# Altium schematic sheet Power.SchDoc
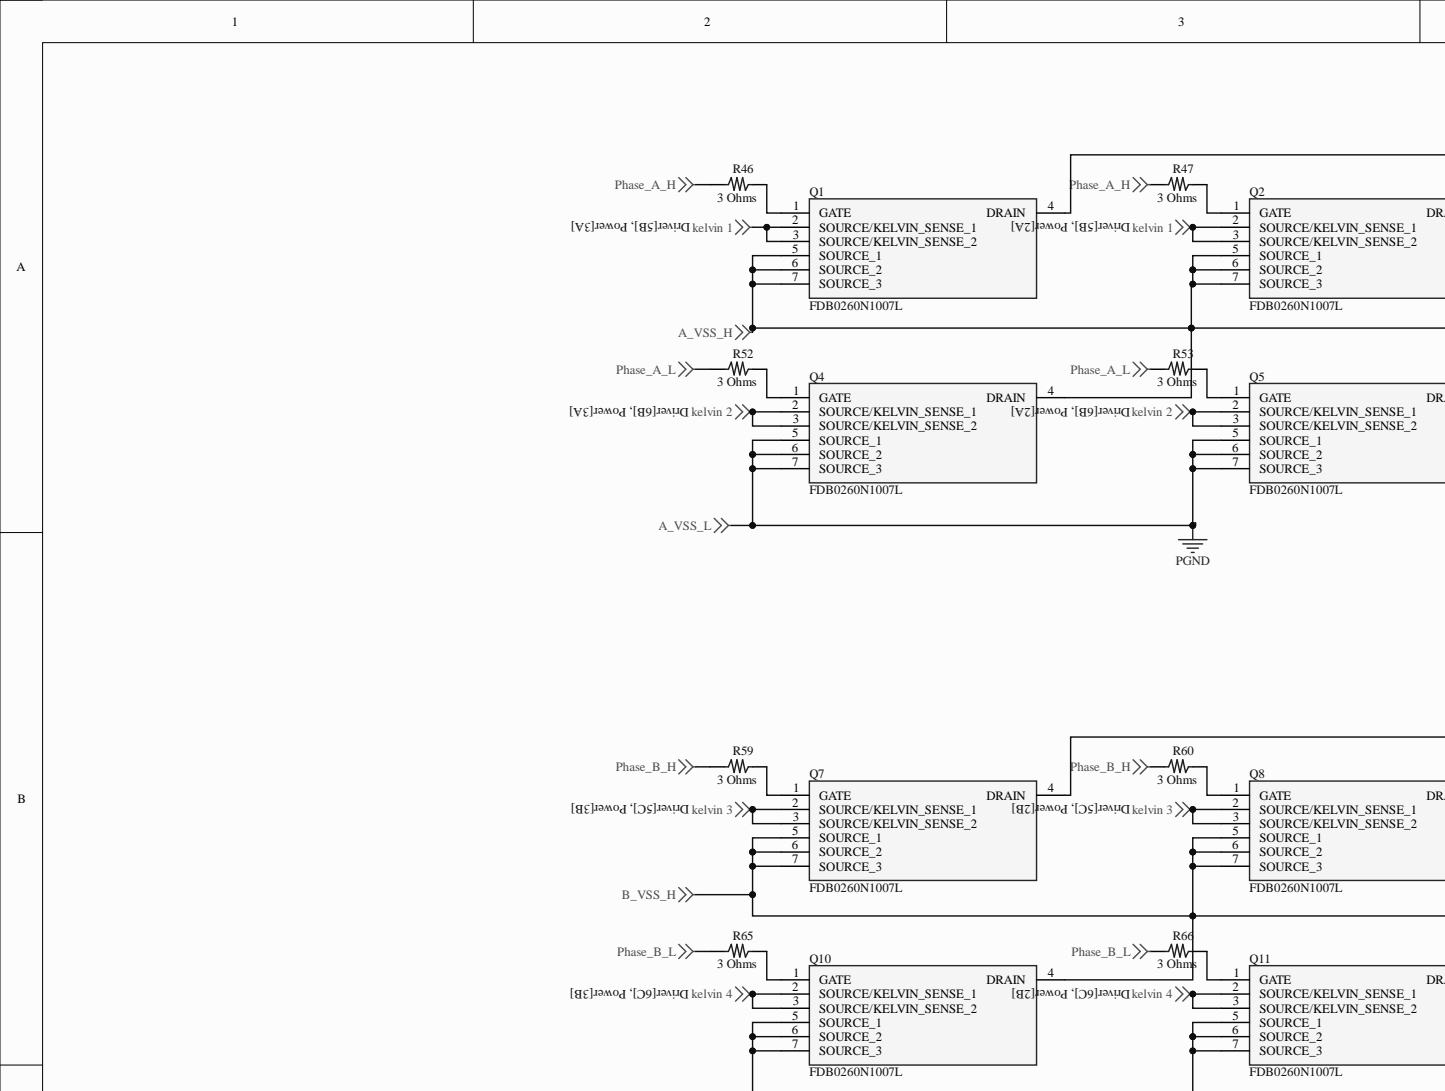
[diagram p0: Power.SchDoc, top left]
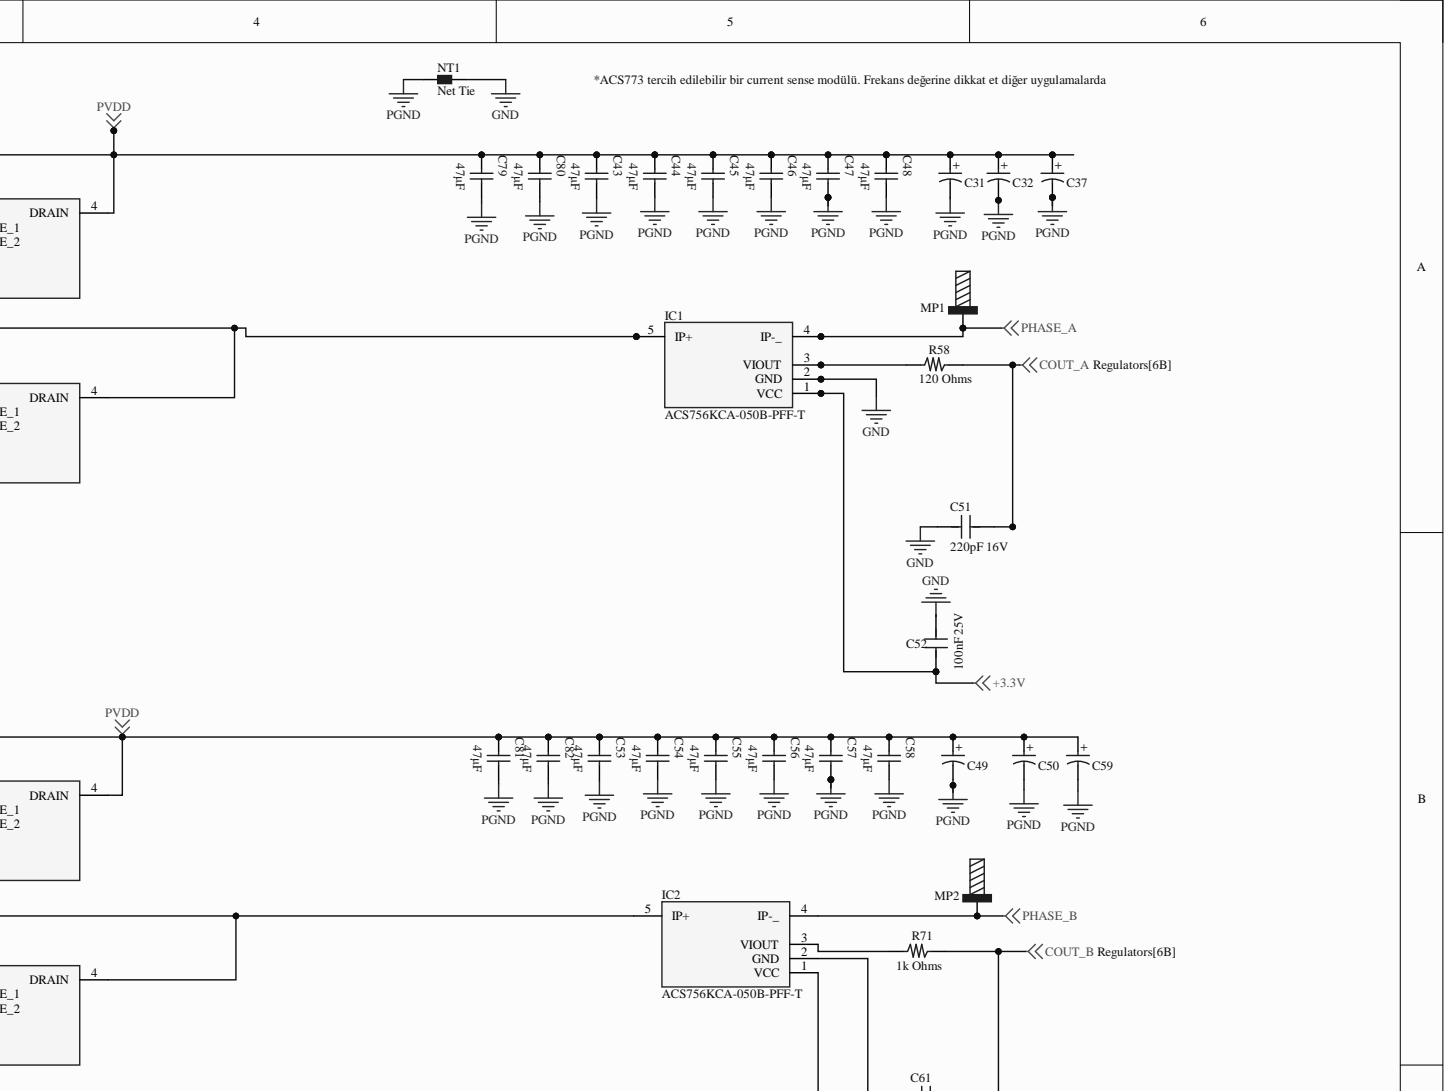
[diagram p1: Power.SchDoc, top right]
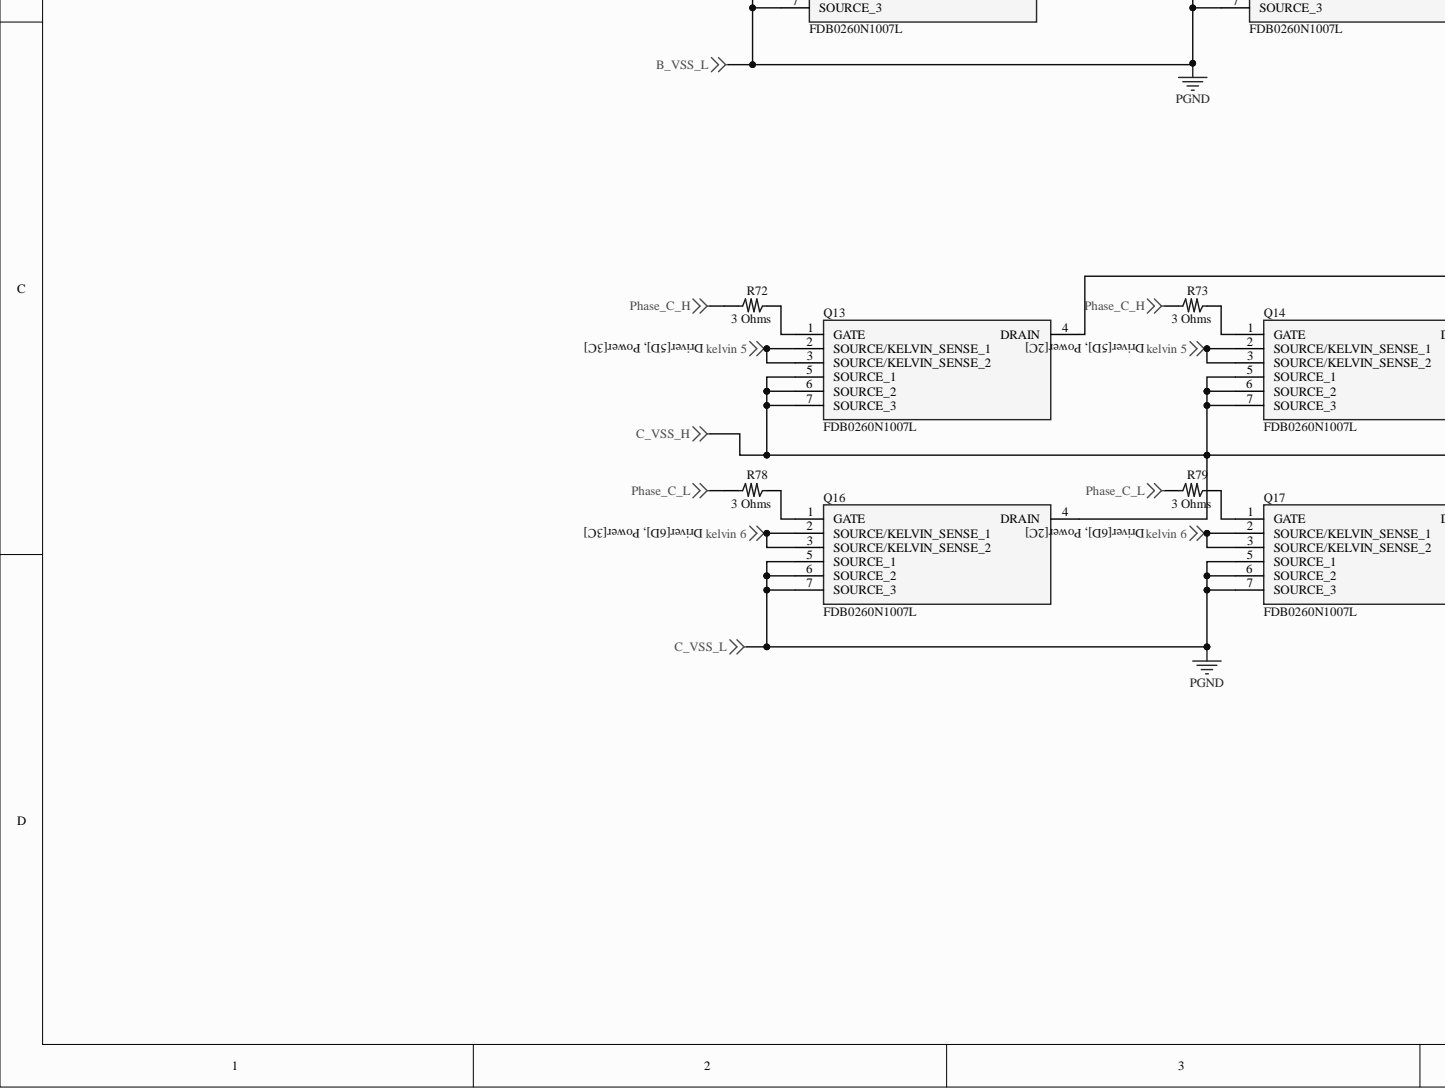
[diagram p2: Power.SchDoc, bottom left]
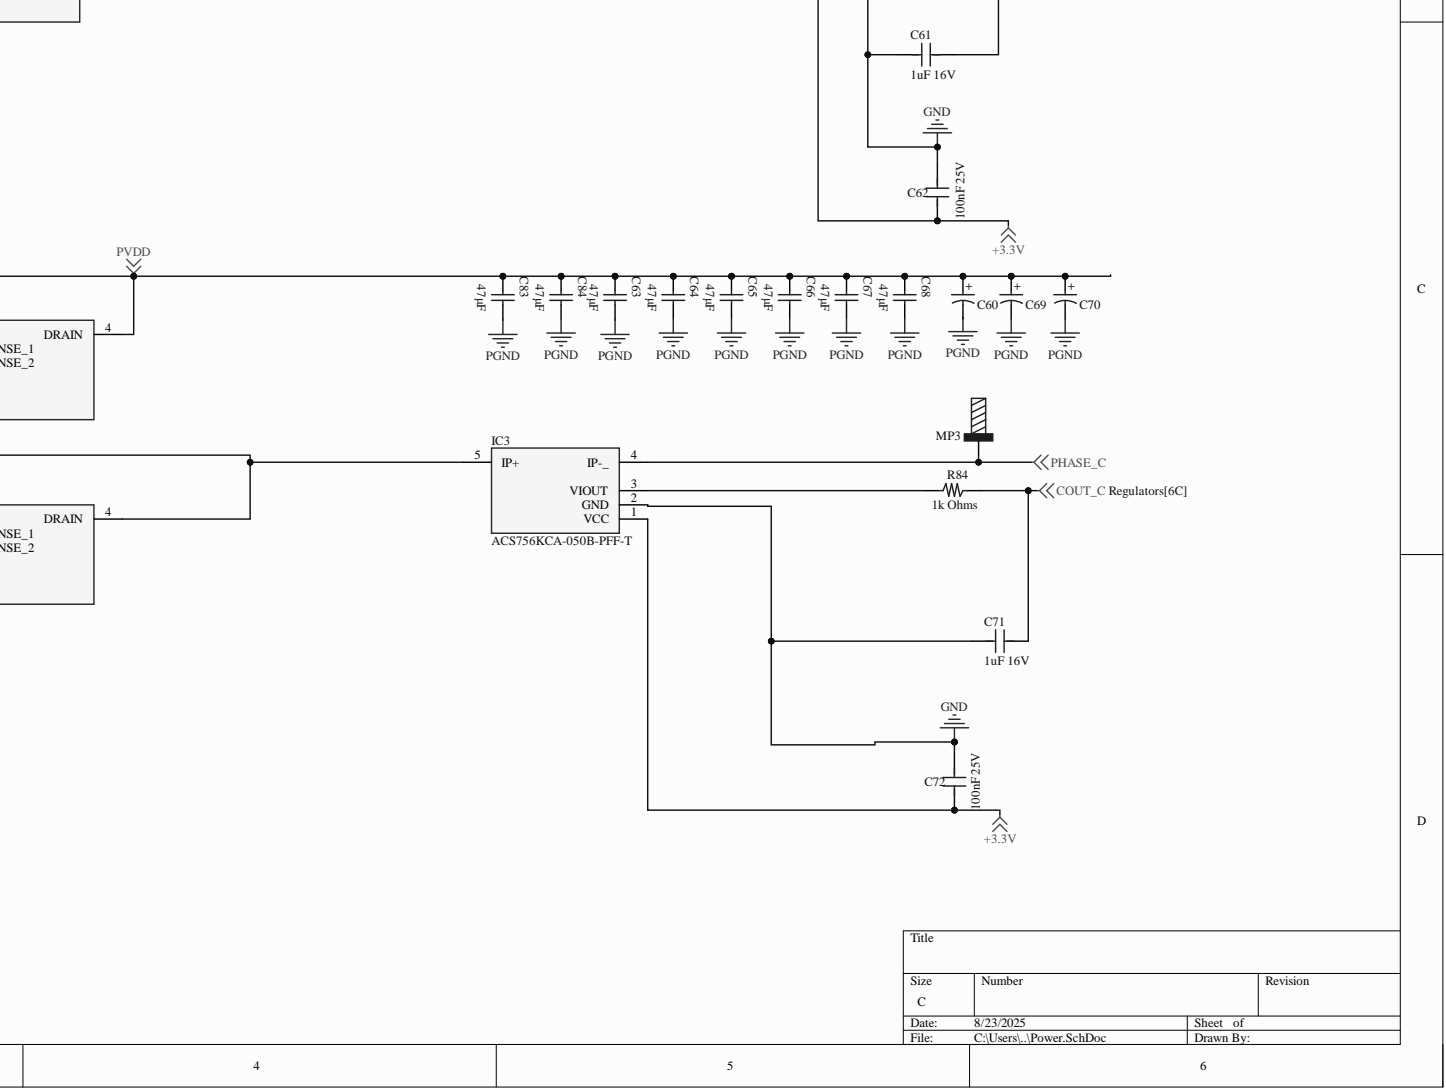
[diagram p3: Power.SchDoc, bottom right]

## Parts
C31  470µF  [WCAP-ATUL_D18H31.5]  (value not drawn)
C32  470µF  [WCAP-ATUL_D18H31.5]  (value not drawn)
C37  470µF  [WCAP-ATUL_D18H31.5]  (value not drawn)
C43  47μF  [WCAP-CSGP_1210_L3.2W2.5H2.5]
C44  47μF  [WCAP-CSGP_1210_L3.2W2.5H2.5]
C45  47μF  [WCAP-CSGP_1210_L3.2W2.5H2.5]
C46  47μF  [WCAP-CSGP_1210_L3.2W2.5H2.5]
C47  47μF  [WCAP-CSGP_1210_L3.2W2.5H2.5]
C48  47μF  [WCAP-CSGP_1210_L3.2W2.5H2.5]
C49  470µF  [WCAP-ATUL_D18H31.5]  (value not drawn)
C50  470µF  [WCAP-ATUL_D18H31.5]  (value not drawn)
C51  220pF 16V  [0603_CAP]
C52  100nF 25V  [0603_CAP]
C53  47μF  [WCAP-CSGP_1210_L3.2W2.5H2.5]
C54  47μF  [WCAP-CSGP_1210_L3.2W2.5H2.5]
C55  47μF  [WCAP-CSGP_1210_L3.2W2.5H2.5]
C56  47μF  [WCAP-CSGP_1210_L3.2W2.5H2.5]
C57  47μF  [WCAP-CSGP_1210_L3.2W2.5H2.5]
C58  47μF  [WCAP-CSGP_1210_L3.2W2.5H2.5]
C59  470µF  [WCAP-ATUL_D18H31.5]  (value not drawn)
C60  470µF  [WCAP-ATUL_D18H31.5]  (value not drawn)
C61  1uF 16V  [0603_CAP]
C62  100nF 25V  [0603_CAP]
C63  47μF  [WCAP-CSGP_1210_L3.2W2.5H2.5]
C64  47μF  [WCAP-CSGP_1210_L3.2W2.5H2.5]
C65  47μF  [WCAP-CSGP_1210_L3.2W2.5H2.5]
C66  47μF  [WCAP-CSGP_1210_L3.2W2.5H2.5]
C67  47μF  [WCAP-CSGP_1210_L3.2W2.5H2.5]
C68  47μF  [WCAP-CSGP_1210_L3.2W2.5H2.5]
C69  470µF  [WCAP-ATUL_D18H31.5]  (value not drawn)
C70  470µF  [WCAP-ATUL_D18H31.5]  (value not drawn)
C71  1uF 16V  [0603_CAP]
C72  100nF 25V  [0603_CAP]
C79  47μF  [WCAP-CSGP_1210_L3.2W2.5H2.5]
C80  47μF  [WCAP-CSGP_1210_L3.2W2.5H2.5]
C81  47μF  [WCAP-CSGP_1210_L3.2W2.5H2.5]
C82  47μF  [WCAP-CSGP_1210_L3.2W2.5H2.5]
C83  47μF  [WCAP-CSGP_1210_L3.2W2.5H2.5]
C84  47μF  [WCAP-CSGP_1210_L3.2W2.5H2.5]
IC1  ACS756KCA-050B-PFF-T  [ACS758]
IC2  ACS756KCA-050B-PFF-T  [ACS758]
IC3  ACS756KCA-050B-PFF-T  [ACS758]
MP1  M6 x 10mm  [7461098_chemical surface]  (value not drawn)
MP2  M6 x 10mm  [7461098_chemical surface]  (value not drawn)
MP3  M6 x 10mm  [7461098_chemical surface]  (value not drawn)
NT1  Net Tie  [NET TIE]
Q1  FDB0260N1007L  [FDB0260N1007L]
Q2  FDB0260N1007L  [FDB0260N1007L]
Q4  FDB0260N1007L  [FDB0260N1007L]
Q5  FDB0260N1007L  [FDB0260N1007L]
Q7  FDB0260N1007L  [FDB0260N1007L]
Q8  FDB0260N1007L  [FDB0260N1007L]
Q10  FDB0260N1007L  [FDB0260N1007L]
Q11  FDB0260N1007L  [FDB0260N1007L]
Q13  FDB0260N1007L  [FDB0260N1007L]
Q14  FDB0260N1007L  [FDB0260N1007L]
Q16  FDB0260N1007L  [FDB0260N1007L]
Q17  FDB0260N1007L  [FDB0260N1007L]
R46  3 Ohms  [0805_RES]
R47  3 Ohms  [0805_RES]
R52  3 Ohms  [0805_RES]
R53  3 Ohms  [0805_RES]
R58  120 Ohms  [0603_RES]
R59  3 Ohms  [0805_RES]
R60  3 Ohms  [0805_RES]
R65  3 Ohms  [0805_RES]
R66  3 Ohms  [0805_RES]
R71  1k Ohms  [0603_RES]
R72  3 Ohms  [0805_RES]
R73  3 Ohms  [0805_RES]
R78  3 Ohms  [0805_RES]
R79  3 Ohms  [0805_RES]
R84  1k Ohms  [0603_RES]

## Nets
+3.3V: C52.1 C62.1 C72.1 IC1.1(VCC) IC2.1(VCC) IC3.1(VCC)
A_VSS_H: IC1.5(IP+) Q1.5(SOURCE_1) Q1.6(SOURCE_2) Q1.7(SOURCE_3) Q2.5(SOURCE_1) Q2.6(SOURCE_2) Q2.7(SOURCE_3) Q4.4(DRAIN) Q5.4(DRAIN)
A_VSS_L: C31.2 C32.2 C37.2 C43.2 C44.2 C45.2 C46.2 C47.2 C48.2 C49.2 C50.2 C53.2 C54.2 C55.2 C56.2 C57.2 C58.2 C59.2 C60.2 C63.2 C64.2 C65.2 C66.2 C67.2 C68.2 C69.2 C70.2 C79.2 C80.2 C81.2 C82.2 C83.2 C84.2 NT1.1 Q4.5(SOURCE_1) Q4.6(SOURCE_2) Q4.7(SOURCE_3) Q5.5(SOURCE_1) Q5.6(SOURCE_2) Q5.7(SOURCE_3) Q10.5(SOURCE_1) Q10.6(SOURCE_2) Q10.7(SOURCE_3) Q11.5(SOURCE_1) Q11.6(SOURCE_2) Q11.7(SOURCE_3) Q16.5(SOURCE_1) Q16.6(SOURCE_2) Q16.7(SOURCE_3) Q17.5(SOURCE_1) Q17.6(SOURCE_2) Q17.7(SOURCE_3)
B_VSS_H: IC2.5(IP+) Q7.5(SOURCE_1) Q7.6(SOURCE_2) Q7.7(SOURCE_3) Q8.5(SOURCE_1) Q8.6(SOURCE_2) Q8.7(SOURCE_3) Q10.4(DRAIN) Q11.4(DRAIN)
C_VSS_H: IC3.5(IP+) Q13.5(SOURCE_1) Q13.6(SOURCE_2) Q13.7(SOURCE_3) Q14.5(SOURCE_1) Q14.6(SOURCE_2) Q14.7(SOURCE_3) Q16.4(DRAIN) Q17.4(DRAIN)
COUT_A: C51.2 R58.2
COUT_B: C61.2 R71.2
COUT_C: C71.2 R84.2
GND: C51.1 C52.2 C61.1 C62.2 C71.1 C72.2 IC1.2(GND) IC2.2(GND) IC3.2(GND) NT1.2
kelvin 1: Q1.2(SOURCE/KELVIN_SENSE_1) Q1.3(SOURCE/KELVIN_SENSE_2) Q2.2(SOURCE/KELVIN_SENSE_1) Q2.3(SOURCE/KELVIN_SENSE_2)
kelvin 2: Q4.2(SOURCE/KELVIN_SENSE_1) Q4.3(SOURCE/KELVIN_SENSE_2) Q5.2(SOURCE/KELVIN_SENSE_1) Q5.3(SOURCE/KELVIN_SENSE_2)
kelvin 3: Q7.2(SOURCE/KELVIN_SENSE_1) Q7.3(SOURCE/KELVIN_SENSE_2) Q8.2(SOURCE/KELVIN_SENSE_1) Q8.3(SOURCE/KELVIN_SENSE_2)
kelvin 4: Q10.2(SOURCE/KELVIN_SENSE_1) Q10.3(SOURCE/KELVIN_SENSE_2) Q11.2(SOURCE/KELVIN_SENSE_1) Q11.3(SOURCE/KELVIN_SENSE_2)
kelvin 5: Q13.2(SOURCE/KELVIN_SENSE_1) Q13.3(SOURCE/KELVIN_SENSE_2) Q14.2(SOURCE/KELVIN_SENSE_1) Q14.3(SOURCE/KELVIN_SENSE_2)
kelvin 6: Q16.2(SOURCE/KELVIN_SENSE_1) Q16.3(SOURCE/KELVIN_SENSE_2) Q17.2(SOURCE/KELVIN_SENSE_1) Q17.3(SOURCE/KELVIN_SENSE_2)
PHASE_A: IC1.4(IP-_) MP1.1
Phase_A_H: R46.1 R47.1
Phase_A_L: R52.1 R53.1
PHASE_B: IC2.4(IP-_) MP2.1
Phase_B_H: R59.1 R60.1
Phase_B_L: R65.1 R66.1
PHASE_C: IC3.4(IP-_) MP3.1
Phase_C_H: R72.1 R73.1
Phase_C_L: R78.1 R79.1
PVDD: C31.1 C32.1 C37.1 C43.1 C44.1 C45.1 C46.1 C47.1 C48.1 C49.1 C50.1 C53.1 C54.1 C55.1 C56.1 C57.1 C58.1 C59.1 C60.1 C63.1 C64.1 C65.1 C66.1 C67.1 C68.1 C69.1 C70.1 C79.1 C80.1 C81.1 C82.1 C83.1 C84.1 Q1.4(DRAIN) Q2.4(DRAIN) Q7.4(DRAIN) Q8.4(DRAIN) Q13.4(DRAIN) Q14.4(DRAIN)
NetIC1_3: IC1.3(VIOUT) R58.1
NetIC2_3: IC2.3(VIOUT) R71.1
NetIC3_3: IC3.3(VIOUT) R84.1
NetQ1_1: Q1.1(GATE) R46.2
NetQ2_1: Q2.1(GATE) R47.2
NetQ4_1: Q4.1(GATE) R52.2
NetQ5_1: Q5.1(GATE) R53.2
NetQ7_1: Q7.1(GATE) R59.2
NetQ8_1: Q8.1(GATE) R60.2
NetQ10_1: Q10.1(GATE) R65.2
NetQ11_1: Q11.1(GATE) R66.2
NetQ13_1: Q13.1(GATE) R72.2
NetQ14_1: Q14.1(GATE) R73.2
NetQ16_1: Q16.1(GATE) R78.2
NetQ17_1: Q17.1(GATE) R79.2

## Library data of the parts
C31 C32 C37 C49 C50 C59 C60 C69 C70 = 860040881012: WCAP-ATUL Aluminum Electrolytic Capacitor, Radial, THT, D18 x H31.5mm, 470µF, 100V; Manufacturer: Wurth Elektronik; Capacitance Tolerance: 20%; Dissipation Factor: 9%; Endurance: 10000h; Leakage Current: 470µA; Ripple Current: 1600mA; Technical Reference: ATDL315471M100DSPAEK000; Capacitance: 470µF; Category: Aluminum Electrolytic Capacitors; Component Kind: Standard; ComponentLink1Description: Website Link; ComponentLink1URL: https://www.we-online.com/redexpert/article/860040881012?ad; ComponentLink2Description: Datasheet Link; ComponentLink2URL: https://www.we-online.com/redexpert/spec/860040881012?ad; Diameter: 18mm; Height: 31.5mm; Library Name: Altium_WCAP-ATUL (rev22e) (1).IntLib; Manufacturer Part Number: 860040881012; Match Code: WCAP-ATUL; Mount: Through Hole; Operating Temperature Max: 105°C; Operating Temperature Min: -40°C; Packaging: Ammo Pack; Rated Voltage (DC): 100V; Simulation: 860040881012_470uF
C43-C48 C53-C58 C63-C68 C79-C84 = 885012109007: WCAP-CSGP Multilayer Ceramic Chip Capacitor, General Purpose, size 1210, X5R, 47μF, 10V; Manufacturer: Wurth Elektronik; Capacitance Tolerance: +/-20%; Case/Size Code: 1210; Dissipation Factor: 10%; Insulation Resistance: 0.002GΩ; Technical Reference: X5R1210476M010DFCT10000; Capacitance: 47μF; Category: Multilayer Ceramic Chip Capacitors; Ceramic Type: X5R; Component Kind: Standard; ComponentLink1Description: Website Link; ComponentLink1URL: https://www.we-online.com/redexpert/article/885012109007?ad; ComponentLink2Description: Datasheet Link; ComponentLink2URL: https://www.we-online.com/redexpert/spec/885012109007?ad; Height: 2.5mm; Length: 3.2mm; Library Name: Altium_WCAP-CSGP (rev24c).IntLib; Manufacturer Part Number: 885012109007; Match Code: WCAP-CSGP; Max Operating Temperature: 85°C; Min Operating Temperature: -55°C; Mount: Surface Mount; Packaging: Tape and Reel; Rated Voltage (DC): 10V; Simulation: 1210_885012109007_47uF; Width: 2.5mm
C51 C61 C71 = CAP_0603: CL10B105KO8NNNC - MLCC (1608) 0603 1uF 16VDC ±10% X7R; Component Kind: Standard; Library Name: HidroanaSCHLib.SchLib
  C51: Value: 220pF 16V
  C61 C71: Value: 1uF 16V
C52 C62 C72 = CAP_0603: CL10B105KO8NNNC - MLCC (1608) 0603 1uF 16VDC ±10% X7R; Value: 1uF 16V; Component Kind: Standard; Library Name: HidroanaSCHLib.SchLib
IC1-IC3 = ACS756KCA-050B-PFF-T: Integrated Circuit; Manufacturer_Name: Allegro Microsystems; Arrow Part Number: ACS756KCA-050B-PFF-T; Arrow Price/Stock: https://www.arrow.com/en/products/acs756kca-050b-pff-t/allegro-microsystems; Component Kind: Standard; Datasheet Link: https://www.allegromicro.com/~/media/Files/Datasheets/ACS756-Datasheet.ashx; Height: 7.1mm; Library Name: SamacSys (1).SchLib; Manufacturer_Part_Number: ACS756KCA-050B-PFF-T
MP1-MP3 = 7461098: WP-SHFU REDCUBE PRESS-FIT with external thread, L13W13H15.5mm, M6 x 10mm, 250A; Manufacturer: Wurth Elektronik; Surface: Tin; Category: REDCUBE PRESS-FIT; Component Kind: Standard; ComponentLink1Description: Website Link; ComponentLink1URL: https://www.we-online.com/redexpert/article/7461098?ad; ComponentLink2Description: Datasheet Link; ComponentLink2URL: https://www.we-online.com/redexpert/spec/7461098?ad; Height: 15.5mm; Length: 13mm; Library Name: Altium_WP-SHFU (rev22b).IntLib; Manufacturer Part Number: 7461098; Match Code: WP-SHFU; Material: Brass; Mount: Press Fit; Number of Pins: 25; Operating Temperature Max: 150°C; Operating Temperature Min: -55°C; Packaging: Bulk; Rated Current: 250A; Thread Length: 10mm; Thread Size: M6; Width: 13mm
NT1 = Net Tie: 1206 size; Component Kind: Net Tie; Library Name: HidroanaSCHLib.SchLib
Q1 Q2 Q4 Q5 Q7 Q8 Q10 Q11 Q13 Q14 Q16 Q17 = FDB0260N1007L: MOSFET 100V TO263 7L JEDEC GREEN EMC; Manufacturer_Name: onsemi; Geometry.Height: 4.7mm; Arrow Part Number: FDB0260N1007L; Arrow Price/Stock: https://www.arrow.com/en/products/fdb0260n1007l/on-semiconductor; Component Kind: Standard; Datasheet Link: https://componentsearchengine.com/Datasheets/2/FDB0260N1007L.pdf; Library Name: FDB0260N1007L.SchLib; Manufacturer_Part_Number: FDB0260N1007L; Mouser Part Number: 512-FDB0260N1007L; Mouser Price/Stock: https://www.mouser.co.uk/ProductDetail/ON-Semiconductor-Fairchild/FDB0260N1007L?qs=lDZPRidwxxtoCZGWdM7x%2FA%3D%3D
R46 R47 R52 R53 R59 R60 R65 R66 R72 R73 R78 R79 = RES_0805: 0805; Power: 1/8 W; Value: 1k Ohms; Component Kind: Standard; Library Name: HidroanaSCHLib.SchLib
R58 = 1k Ohms: Component Kind: Standard; Library Name: HidroanaSCHLib.SchLib
R71 R84 = 1k Ohms: Component Kind: Standard; Library Name: HidroanaSCHLib.SchLib
